# Altium schematic sheet TopSheet.SchDoc(TopSheet)
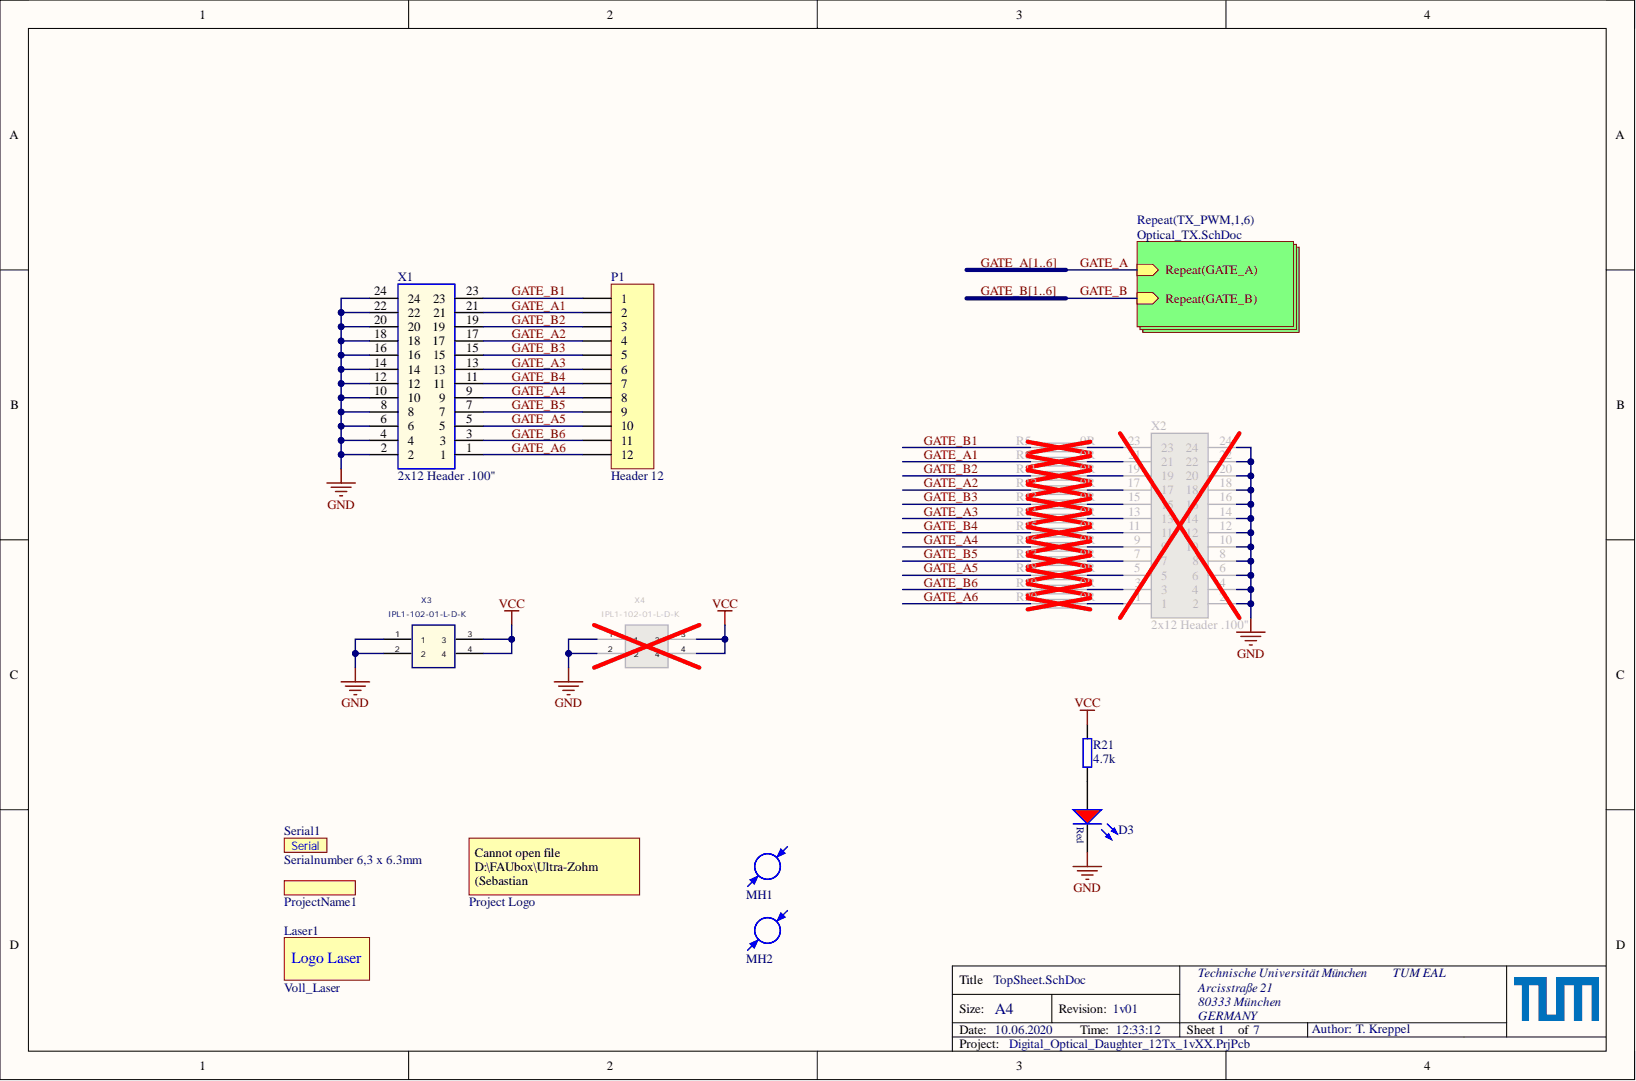
[diagram p0: TopSheet.SchDoc]

## Parts
D3  Emitting Color: Red  [WL-SMCW_0603]  (hidden comment: 150060RS75000)
Laser1  Voll_Laser  [Voll_Laser]
LOGO1  Project Logo  [Logo_Partners_1]  (no BOM)
MH1  MH_2.8  [MH_2.8]  (value not drawn)
MH2  MH_2.8  [MH_2.8]  (value not drawn)
P1  Header 12  [HDR1X12]
ProjectName1  Project Name, Rev  [ID_ProjectRev]  (value not drawn)  (no BOM)
R5  0R  [R_0603_N]
R6  0R  [R_0603_N]
R11  0R  [R_0603_N]
R12  0R  [R_0603_N]
R13  0R  [R_0603_N]
R14  0R  [R_0603_N]
R15  0R  [R_0603_N]
R16  0R  [R_0603_N]
R17  0R  [R_0603_N]
R18  0R  [R_0603_N]
R19  0R  [R_0603_N]
R20  0R  [R_0603_N]
R21  4.7k  [R_0603_N]
Serial1  Serialnumber 6,3 x 6.3mm  [Serial_6.3x6.3mm]  (no BOM)
X1  2x12 Header .100''  [TST-112-01-G-D]
X2  2x12 Header .100''  [TST-112-01-G-D]
X3  IPL1-102-01-L-D-K  [IPL1-102-01-L-D-K]
X4  IPL1-102-01-L-D-K  [IPL1-102-01-L-D-K]

## Nets
GND: D3.1 X1.2 X1.4 X1.6 X1.8 X1.10 X1.12 X1.14 X1.16 X1.18 X1.20 X1.22 X1.24 X2.2 X2.4 X2.6 X2.8 X2.10 X2.12 X2.14 X2.16 X2.18 X2.20 X2.22 X2.24 X3.1 X3.2 X4.1 X4.2
VCC: R21.2 X3.3 X3.4 X4.3 X4.4
GATE_A1: P1.2 R6.1 X1.21  [also labelled GATE_A]
GATE_A2: P1.4 R12.1 X1.17  [also labelled GATE_A]
GATE_A3: P1.6 R14.1 X1.13  [also labelled GATE_A]
GATE_A4: P1.8 R16.1 X1.9  [also labelled GATE_A]
GATE_A5: P1.10 R18.1 X1.5  [also labelled GATE_A]
GATE_A6: P1.12 R20.1 X1.1  [also labelled GATE_A]
GATE_B1: P1.1 R5.1 X1.23  [also labelled GATE_B]
GATE_B2: P1.3 R11.1 X1.19  [also labelled GATE_B]
GATE_B3: P1.5 R13.1 X1.15  [also labelled GATE_B]
GATE_B4: P1.7 R15.1 X1.11  [also labelled GATE_B]
GATE_B5: P1.9 R17.1 X1.7  [also labelled GATE_B]
GATE_B6: P1.11 R19.1 X1.3  [also labelled GATE_B]
NetD3_2: D3.2 R21.1
NetR5_2: R5.2 X2.23
NetR6_2: R6.2 X2.21
NetR11_2: R11.2 X2.19
NetR12_2: R12.2 X2.17
NetR13_2: R13.2 X2.15
NetR14_2: R14.2 X2.13
NetR15_2: R15.2 X2.11
NetR16_2: R16.2 X2.9
NetR17_2: R17.2 X2.7
NetR18_2: R18.2 X2.5
NetR19_2: R19.2 X2.3
NetR20_2: R20.2 X2.1

## Library data of the parts
D3 = LED_Red_0603: WL-SMCW SMD Mono-color Chip LED Waterclear, size 0603, Red, 2 V, 140°; Dominant Wavelength: 625 nm; Forward Voltage: 2 V; Luminous Intensity: 250 mcd; MF: Wuerth Elektronik; Peak Wavelength: 630 nm; Component Kind: Standard; ComponentLink1Description: Manufacturer; ComponentLink1URL: http://www.we-online.de; ComponentLink2Description: Datasheet; ComponentLink2URL: http://katalog.we-online.com/led/datasheet/150060RS75000.pdf; MPN: 150060RS75000; Package: 0603; Published Date: Sep. 2017; Size: 0603; Supplier 1: Farnell; Supplier 2: Mouser; Supplier 3: Digi-Key; Supplier Part Number 1: 2322071; Supplier Part Number 2: 710-150060RS75000; Supplier Part Number 3: 732-4978-1-ND; Value: 150060RS75000; Viewing Angle: 140°; Created: 2018_09_06_AGeiger; Library Name: UZOhm_Optical.SchLib
Laser1 = Voll_Laser: Logo Laser Warning Sign; Component Kind: Standard; Library Name: UZOhm_Optical.SchLib
LOGO1 = (no symbol name): LOGO PRINT; Value: Project Logo; Created: 2018_09_18_AGeiger; Library Name: UZOhm_Optical.SchLib
MH1 MH2 = Mounting Hole: Non plated through hole; Component Kind: Standard; Library Name: UZOhm_Optical.SchLib
P1 = Header 12: Header, 12-Pin; Component Kind: Standard; LatestRevisionDate: 17-Jul-2002; LatestRevisionNote: Re-released for DXP Platform.; Library Name: Miscellaneous Connectors.IntLib; Signal Integrity: Connector; Publisher: Altium Limited
ProjectName1 = (no symbol name): Project Name and Revision Number; Value: Project Name, Rev; Created: 2018_11_23_AGeiger; Library Name: UZOhm_Optical.SchLib
R5 R6 R11-R20 = (no symbol name): SMD Resistor 0603; MPN: CRCW06030000Z0EA; MF: Vishay/Dale; Power: 100 mW; Tolerance: 1%; Voltage: 75 V; Component Kind: Standard; Package: 0603; Supplier 1: Mouser; Supplier Part Number 1: 71-CRCW0603-0-E3; Value: 0R; Created: 2018_09_06_AGeiger; Library Name: UZOhm_Optical.SchLib
R21 = (no symbol name): SMD Resistor 0603; MPN: CRCW06034K70JNEAC; MF: Vishay; Power: 100 mW; Tolerance: 5%; Voltage: 75V; Component Kind: Standard; Package: 0603; Supplier 1: Mouser; Supplier Part Number 1: 71-CRCW06034K70JNEAC; Value: 4.7k; Created: 2018_09_06_AGeiger; Library Name: UZOhm_Optical.SchLib
X1 X2 = TST-112-01-G-D: Double Row, 12 positions, Shrouded Terminal Header .100''; MF: Samtec; Component Kind: Standard; MPN: TST-112-01-G-D; Supplier 1: Mouser; Supplier Part Number 1: 200-TST11201GD; Created: 2020_04_Kreppel; Library Name: UZOhm_Optical.SchLib
X3 X4 = IPL1-102-XX-X-D-X: 2.54mm Double Row Terminal Assembly, Right-Angled; Manufacturer: SAMTEC; Component Kind: Standard; MPN: IPL1-102-01-L-D-K; Value: IPL1-102-01-L-D-K; Created: 2018_05_02_AGeiger; Library Name: UZOhm_Optical.SchLib
Serial1 = (no symbol name): Description: Serialnumber 6,3 x 6.3mm; Value: Serialnumber 6,3 x 6.3mm; Created: 2018_09_18_AGeiger; Library Name: UZOhm_Optical.SchLib
